# Altium schematic sheet 风火轮（单面）.SchDoc(风火轮（单面）)
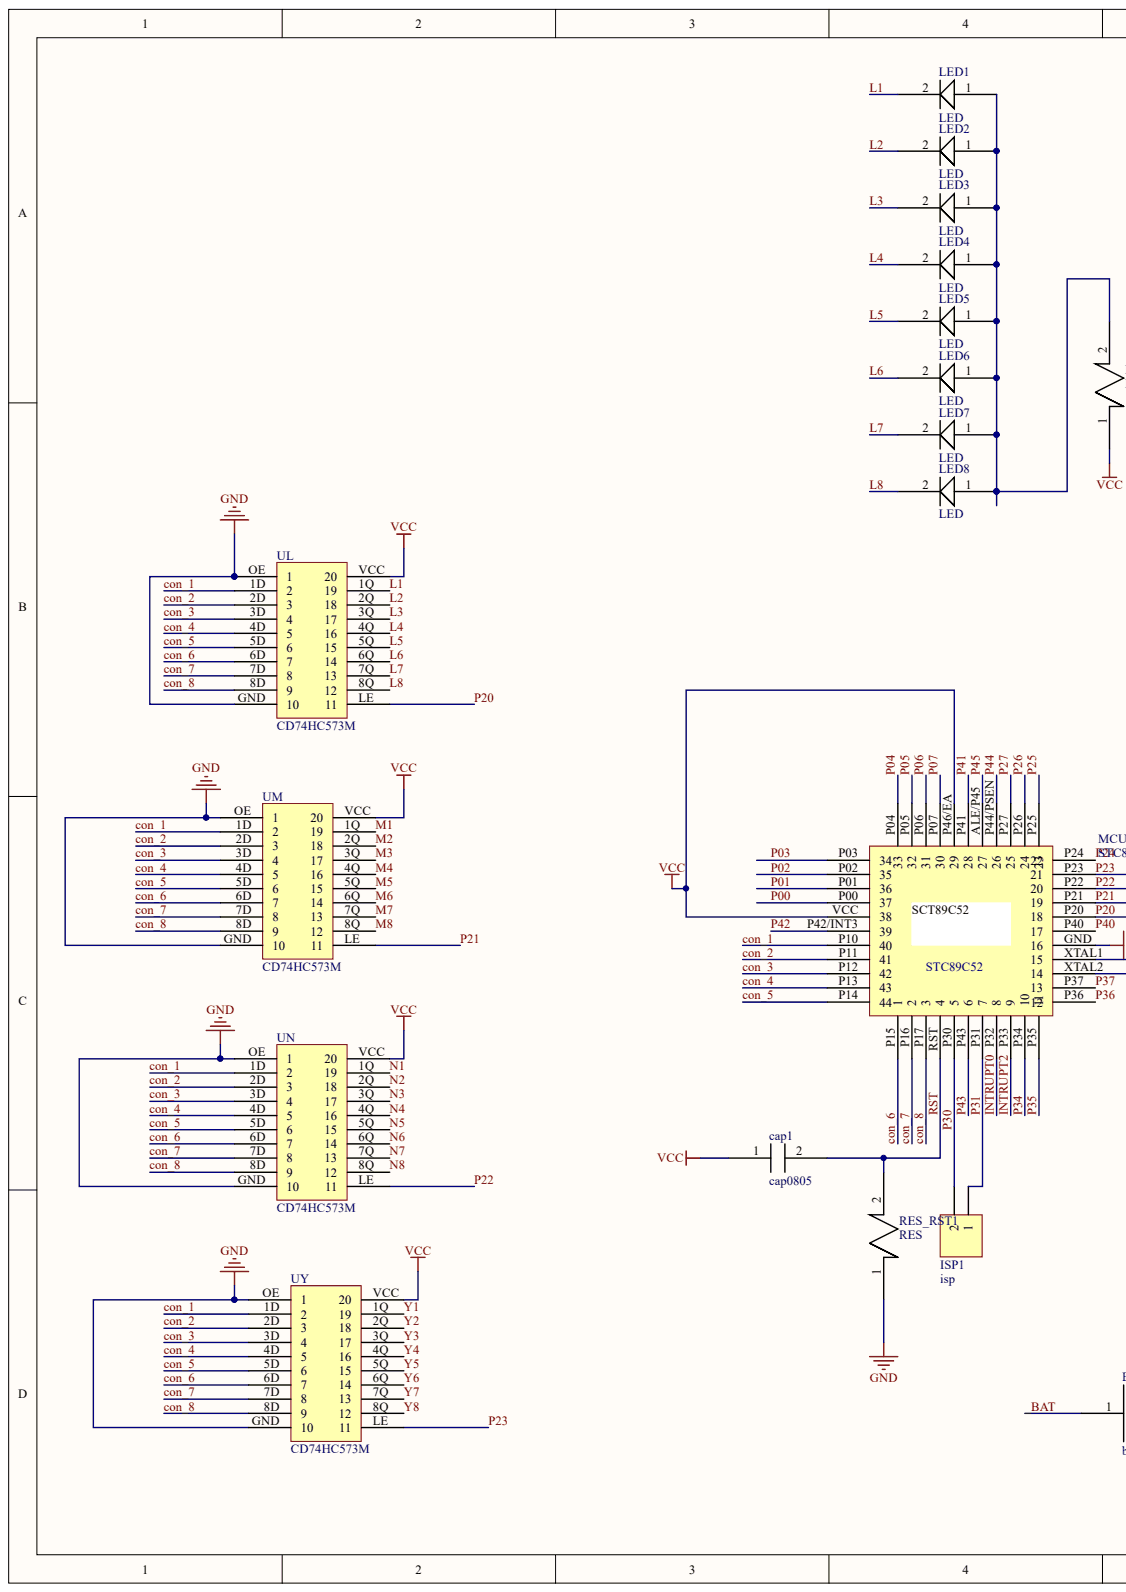
[diagram p0: 风火轮（单面）.SchDoc, left]
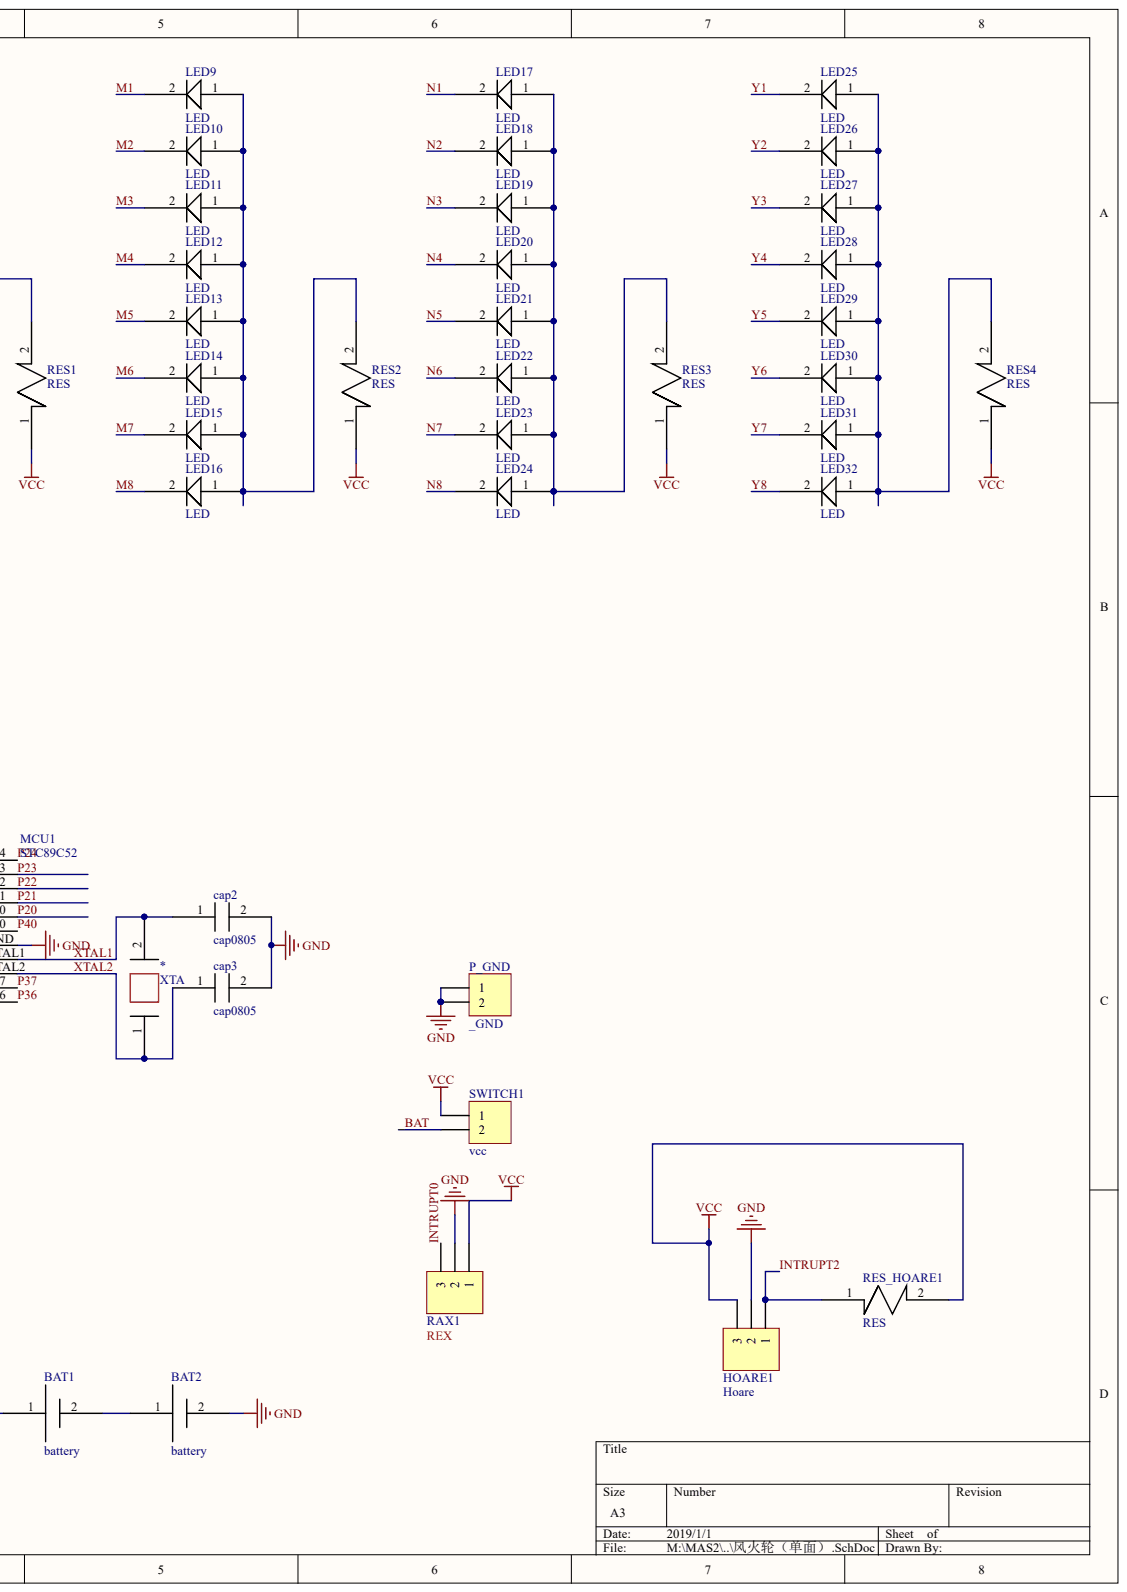
[diagram p1: 风火轮（单面）.SchDoc, right]

## Parts
*  XTA
BAT1  battery
BAT2  battery
cap1  cap0805
cap2  cap0805
cap3  cap0805
HOARE1  Hoare
ISP1  isp
LED1  LED
LED2  LED
LED3  LED
LED4  LED
LED5  LED
LED6  LED
LED7  LED
LED8  LED
LED9  LED
LED10  LED
LED11  LED
LED12  LED
LED13  LED
LED14  LED
LED15  LED
LED16  LED
LED17  LED
LED18  LED
LED19  LED
LED20  LED
LED21  LED
LED22  LED
LED23  LED
LED24  LED
LED25  LED
LED26  LED
LED27  LED
LED28  LED
LED29  LED
LED30  LED
LED31  LED
LED32  LED
MCU1  STC89C52
P_GND  _GND
RAX1  REX
RES1  RES
RES2  RES
RES3  RES
RES4  RES
RES_HOARE1  RES
RES_RST1  RES
SWITCH1  vcc
UL  CD74HC573M
UM  CD74HC573M
UN  CD74HC573M
UY  CD74HC573M

## Nets
GND: BAT2.2 cap2.2 cap3.2 HOARE1.2 MCU1.GND P_GND.1 P_GND.2 RAX1.2 RES_RST1.1 UL.GND UL.OE UM.GND UM.OE UN.GND UN.OE UY.GND UY.OE
VCC: cap1.1 HOARE1.3 MCU1.P46/EA MCU1.VCC RAX1.1 RES1.1 RES2.1 RES3.1 RES4.1 RES_HOARE1.2 SWITCH1.1 UL.VCC UM.VCC UN.VCC UY.VCC
_BAT: BAT1.1 SWITCH1.2
CON_1: MCU1.P10 UL.1D UM.1D UN.1D UY.1D
CON_2: MCU1.P11 UL.2D UM.2D UN.2D UY.2D
CON_3: MCU1.P12 UL.3D UM.3D UN.3D UY.3D
CON_4: MCU1.P13 UL.4D UM.4D UN.4D UY.4D
CON_5: MCU1.P14 UL.5D UM.5D UN.5D UY.5D
CON_6: MCU1.P15 UL.6D UM.6D UN.6D UY.6D
CON_7: MCU1.P16 UL.7D UM.7D UN.7D UY.7D
CON_8: MCU1.P17 UL.8D UM.8D UN.8D UY.8D
INTRUPT0: MCU1.P32 RAX1.3
INTRUPT2: HOARE1.1 MCU1.P33 RES_HOARE1.1
L1: LED1.2 UL.1Q
L2: LED2.2 UL.2Q
L3: LED3.2 UL.3Q
L4: LED4.2 UL.4Q
L5: LED5.2 UL.5Q
L6: LED6.2 UL.6Q
L7: LED7.2 UL.7Q
L8: LED8.2 UL.8Q
M1: LED9.2 UM.1Q
M2: LED10.2 UM.2Q
M3: LED11.2 UM.3Q
M4: LED12.2 UM.4Q
M5: LED13.2 UM.5Q
M6: LED14.2 UM.6Q
M7: LED15.2 UM.7Q
M8: LED16.2 UM.8Q
N1: LED17.2 UN.1Q
N2: LED18.2 UN.2Q
N3: LED19.2 UN.3Q
N4: LED20.2 UN.4Q
N5: LED21.2 UN.5Q
N6: LED22.2 UN.6Q
N7: LED23.2 UN.7Q
N8: LED24.2 UN.8Q
P00: MCU1.P00
P01: MCU1.P01
P02: MCU1.P02
P03: MCU1.P03
P04: MCU1.P04
P05: MCU1.P05
P06: MCU1.P06
P07: MCU1.P07
P20: MCU1.P20 UL.LE
P21: MCU1.P21 UM.LE
P22: MCU1.P22 UN.LE
P23: MCU1.P23 UY.LE
P24: MCU1.P24
P25: MCU1.P25
P26: MCU1.P26
P27: MCU1.P27
P30: ISP1.2 MCU1.P30
P31: ISP1.1 MCU1.P31
P34: MCU1.P34
P35: MCU1.P35
P36: MCU1.P36
P37: MCU1.P37
P40: MCU1.P40
P41: MCU1.P41
P42: MCU1.P42/INT3
P43: MCU1.P43
P44: MCU1.P44/PSEN
P45: MCU1.ALE/P45
RST: cap1.2 MCU1.RST RES_RST1.2
XTAL1: *.2 cap2.1 MCU1.XTAL1
XTAL2: *.1 cap3.1 MCU1.XTAL2
Y1: LED25.2 UY.1Q
Y2: LED26.2 UY.2Q
Y3: LED27.2 UY.3Q
Y4: LED28.2 UY.4Q
Y5: LED29.2 UY.5Q
Y6: LED30.2 UY.6Q
Y7: LED31.2 UY.7Q
Y8: LED32.2 UY.8Q
NetBAT1_2: BAT1.2 BAT2.1
NetLED1_1: LED1.1 LED2.1 LED3.1 LED4.1 LED5.1 LED6.1 LED7.1 LED8.1 RES1.2
NetLED9_1: LED9.1 LED10.1 LED11.1 LED12.1 LED13.1 LED14.1 LED15.1 LED16.1 RES2.2
NetLED17_1: LED17.1 LED18.1 LED19.1 LED20.1 LED21.1 LED22.1 LED23.1 LED24.1 RES3.2
NetLED25_1: LED25.1 LED26.1 LED27.1 LED28.1 LED29.1 LED30.1 LED31.1 LED32.1 RES4.2

## Library data of the parts
* BAT1 BAT2 cap1-cap3 HOARE1 ISP1 LED1-LED32 MCU1 P_GND RAX1 RES1-RES4 RES_HOARE1 RES_RST1 SWITCH1 UL UM UN UY = (no symbol name)
  HOARE1 ISP1 P_GND RAX1 SWITCH1: LatestRevisionDate: 17-Jul-2002; LatestRevisionNote: Re-released for DXP Platform.; Publisher: Altium Limited
